# Altium schematic sheet FMC.SchDoc(FMC)
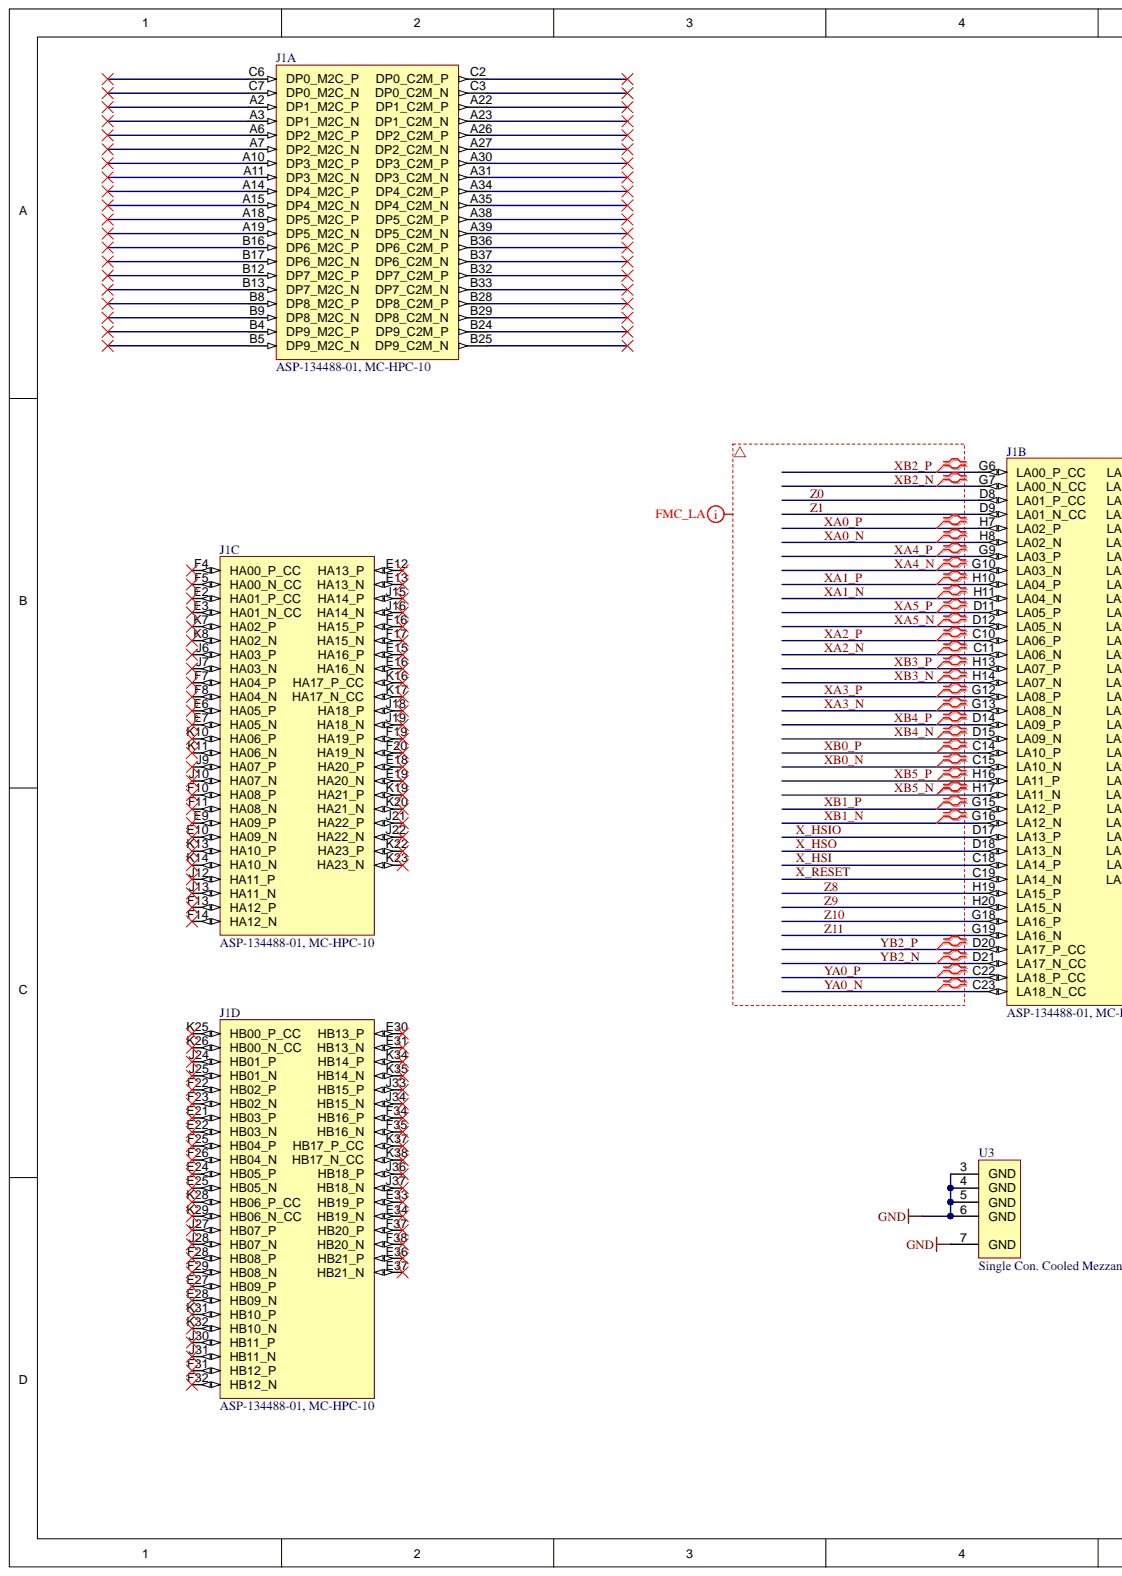
[diagram p0: FMC.SchDoc, left]
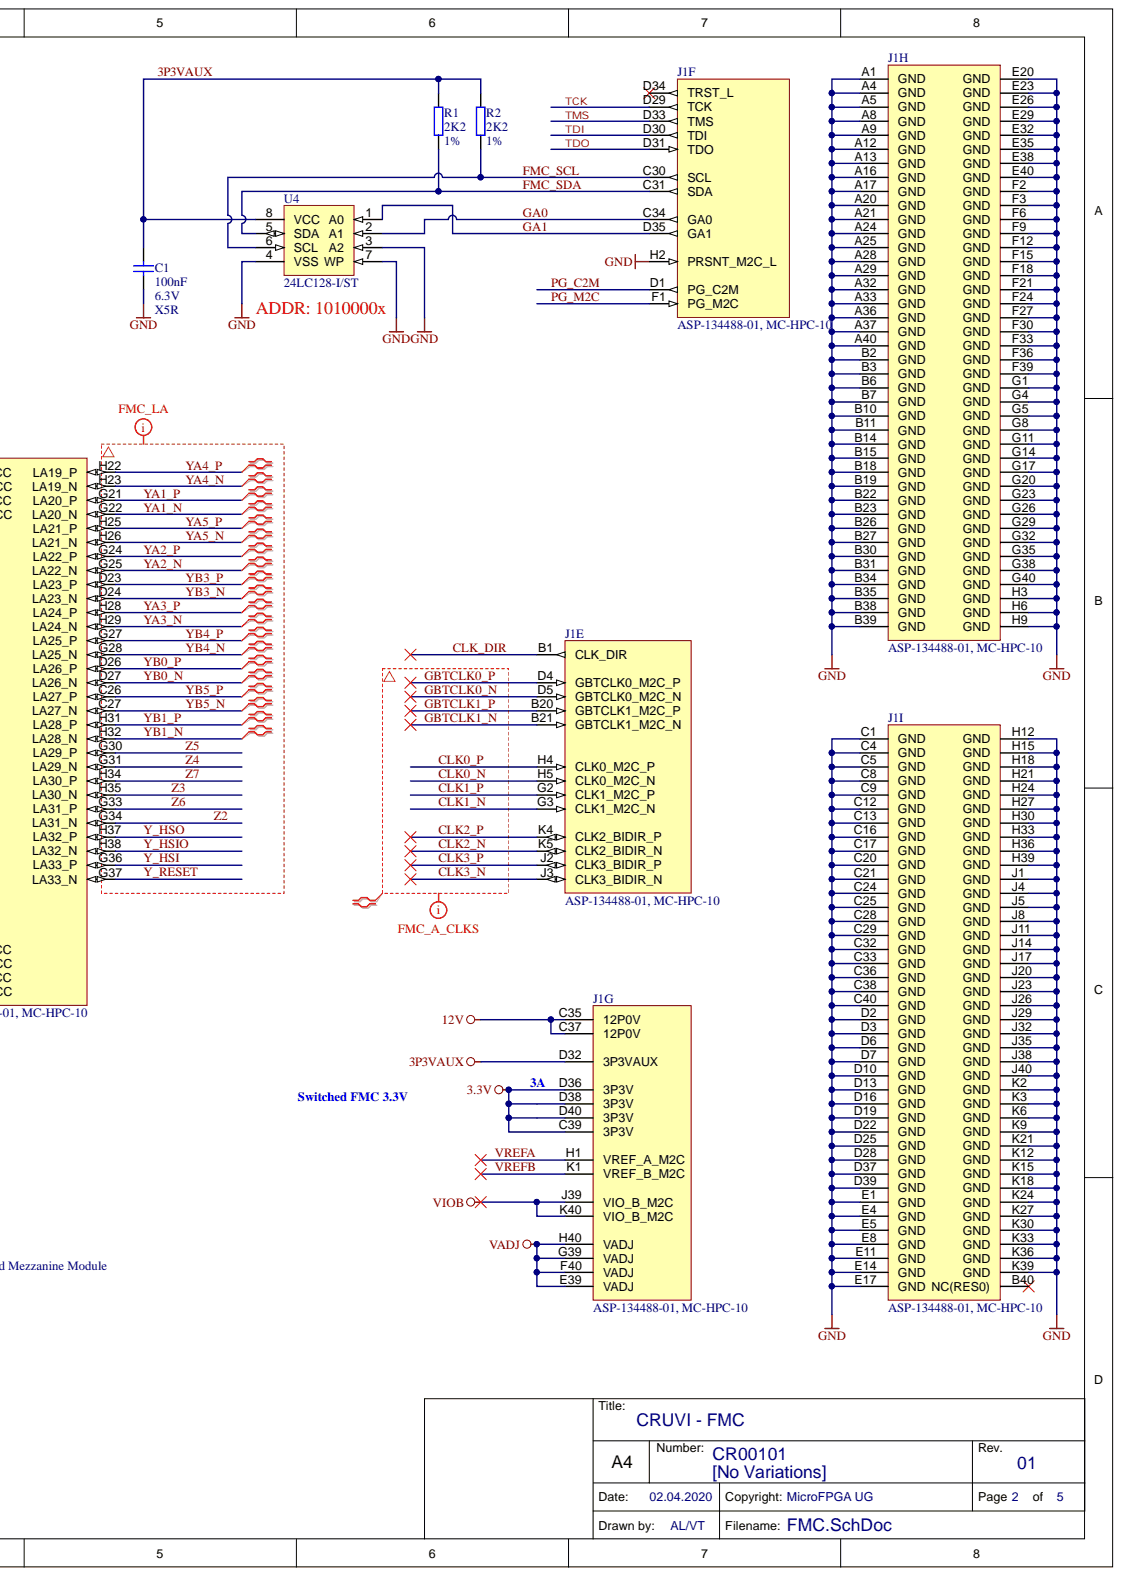
[diagram p1: FMC.SchDoc, right]

## Parts
C1  100nF  Technology: X5R  Voltage: 6.3V  [CAPC0603X33N]
J1  ASP-134488-01, MC-HPC-10  [ASP-134488-01]  (parts A,B,C,D,E,F,G,H,I)
R1  2K2  Tolerance: 1%  [RESC1005X40N]
R2  2K2  Tolerance: 1%  [RESC1005X40N]
U3  Single Con. Cooled Mezzanine Module  Case: Single  [FMC-MM-Single]
U4  24LC128-I/ST  [SOP65P640X120-8N-MC]

## Nets
3.3V: J1.C39(3P3V) J1.D36(3P3V) J1.D38(3P3V) J1.D40(3P3V)
12V: J1.C35(12P0V) J1.C37(12P0V)
GND: C1.1 J1.A1(GND) J1.A4(GND) J1.A5(GND) J1.A8(GND) J1.A9(GND) J1.A12(GND) J1.A13(GND) J1.A16(GND) J1.A17(GND) J1.A20(GND) J1.A21(GND) J1.A24(GND) J1.A25(GND) J1.A28(GND) J1.A29(GND) J1.A32(GND) J1.A33(GND) J1.A36(GND) J1.A37(GND) J1.A40(GND) J1.B2(GND) J1.B3(GND) J1.B6(GND) J1.B7(GND) J1.B10(GND) J1.B11(GND) J1.B14(GND) J1.B15(GND) J1.B18(GND) J1.B19(GND) J1.B22(GND) J1.B23(GND) J1.B26(GND) J1.B27(GND) J1.B30(GND) J1.B31(GND) J1.B34(GND) J1.B35(GND) J1.B38(GND) J1.B39(GND) J1.C1(GND) J1.C4(GND) J1.C5(GND) J1.C8(GND) J1.C9(GND) J1.C12(GND) J1.C13(GND) J1.C16(GND) J1.C17(GND) J1.C20(GND) J1.C21(GND) J1.C24(GND) J1.C25(GND) J1.C28(GND) J1.C29(GND) J1.C32(GND) J1.C33(GND) J1.C36(GND) J1.C38(GND) J1.C40(GND) J1.D2(GND) J1.D3(GND) J1.D6(GND) J1.D7(GND) J1.D10(GND) J1.D13(GND) J1.D16(GND) J1.D19(GND) J1.D22(GND) J1.D25(GND) J1.D28(GND) J1.D37(GND) J1.D39(GND) J1.E1(GND) J1.E4(GND) J1.E5(GND) J1.E8(GND) J1.E11(GND) J1.E14(GND) J1.E17(GND) J1.E20(GND) J1.E23(GND) J1.E26(GND) J1.E29(GND) J1.E32(GND) J1.E35(GND) J1.E38(GND) J1.E40(GND) J1.F2(GND) J1.F3(GND) J1.F6(GND) J1.F9(GND) J1.F12(GND) J1.F15(GND) J1.F18(GND) J1.F21(GND) J1.F24(GND) J1.F27(GND) J1.F30(GND) J1.F33(GND) J1.F36(GND) J1.F39(GND) J1.G1(GND) J1.G4(GND) J1.G5(GND) J1.G8(GND) J1.G11(GND) J1.G14(GND) J1.G17(GND) J1.G20(GND) J1.G23(GND) J1.G26(GND) J1.G29(GND) J1.G32(GND) J1.G35(GND) J1.G38(GND) J1.G40(GND) J1.H2(PRSNT_M2C_L) J1.H3(GND) J1.H6(GND) J1.H9(GND) J1.H12(GND) J1.H15(GND) J1.H18(GND) J1.H21(GND) J1.H24(GND) J1.H27(GND) J1.H30(GND) J1.H33(GND) J1.H36(GND) J1.H39(GND) J1.J1(GND) J1.J4(GND) J1.J5(GND) J1.J8(GND) J1.J11(GND) J1.J14(GND) J1.J17(GND) J1.J20(GND) J1.J23(GND) J1.J26(GND) J1.J29(GND) J1.J32(GND) J1.J35(GND) J1.J38(GND) J1.J40(GND) J1.K2(GND) J1.K3(GND) J1.K6(GND) J1.K9(GND) J1.K12(GND) J1.K15(GND) J1.K18(GND) J1.K21(GND) J1.K24(GND) J1.K27(GND) J1.K30(GND) J1.K33(GND) J1.K36(GND) J1.K39(GND) U3.3(GND) U3.4(GND) U3.5(GND) U3.6(GND) U3.7(GND) U4.3(A2) U4.4(VSS) U4.7(WP)
VADJ: J1.E39(VADJ) J1.F40(VADJ) J1.G39(VADJ) J1.H40(VADJ)
VIOB: J1.J39(VIO_B_M2C) J1.K40(VIO_B_M2C)
3P3VAUX: C1.2 J1.D32(3P3VAUX) R1.1 R2.1 U4.8(VCC)
CLK0_N: J1.H5(CLK0_M2C_N)
CLK0_P: J1.H4(CLK0_M2C_P)
CLK1_N: J1.G3(CLK1_M2C_N)
CLK1_P: J1.G2(CLK1_M2C_P)
CLK2_N: J1.K5(CLK2_BIDIR_N)
CLK2_P: J1.K4(CLK2_BIDIR_P)
CLK3_N: J1.J3(CLK3_BIDIR_N)
CLK3_P: J1.J2(CLK3_BIDIR_P)
CLK_DIR: J1.B1(CLK_DIR)
FMC_SCL: J1.C30(SCL) R2.2 U4.6(SCL)
FMC_SDA: J1.C31(SDA) R1.2 U4.5(SDA)
GA0: J1.C34(GA0) U4.2(A1)
GA1: J1.D35(GA1) U4.1(A0)
GBTCLK0_N: J1.D5(GBTCLK0_M2C_N)
GBTCLK0_P: J1.D4(GBTCLK0_M2C_P)
GBTCLK1_N: J1.B21(GBTCLK1_M2C_N)
GBTCLK1_P: J1.B20(GBTCLK1_M2C_P)
PG_C2M: J1.D1(PG_C2M)
PG_M2C: J1.F1(PG_M2C)
TCK: J1.D29(TCK)
TDI: J1.D30(TDI)
TDO: J1.D31(TDO)
TMS: J1.D33(TMS)
VREFA: J1.H1(VREF_A_M2C)
VREFB: J1.K1(VREF_B_M2C)
X_HSI: J1.C18(LA14_P)
X_HSIO: J1.D17(LA13_P)
X_HSO: J1.D18(LA13_N)
X_RESET: J1.C19(LA14_N)
XA0_N: J1.H8(LA02_N)
XA0_P: J1.H7(LA02_P)
XA1_N: J1.H11(LA04_N)
XA1_P: J1.H10(LA04_P)
XA2_N: J1.C11(LA06_N)
XA2_P: J1.C10(LA06_P)
XA3_N: J1.G13(LA08_N)
XA3_P: J1.G12(LA08_P)
XA4_N: J1.G10(LA03_N)
XA4_P: J1.G9(LA03_P)
XA5_N: J1.D12(LA05_N)
XA5_P: J1.D11(LA05_P)
XB0_N: J1.C15(LA10_N)
XB0_P: J1.C14(LA10_P)
XB1_N: J1.G16(LA12_N)
XB1_P: J1.G15(LA12_P)
XB2_N: J1.G7(LA00_N_CC)
XB2_P: J1.G6(LA00_P_CC)
XB3_N: J1.H14(LA07_N)
XB3_P: J1.H13(LA07_P)
XB4_N: J1.D15(LA09_N)
XB4_P: J1.D14(LA09_P)
XB5_N: J1.H17(LA11_N)
XB5_P: J1.H16(LA11_P)
Y_HSI: J1.G36(LA33_P)
Y_HSIO: J1.H38(LA32_N)
Y_HSO: J1.H37(LA32_P)
Y_RESET: J1.G37(LA33_N)
YA0_N: J1.C23(LA18_N_CC)
YA0_P: J1.C22(LA18_P_CC)
YA1_N: J1.G22(LA20_N)
YA1_P: J1.G21(LA20_P)
YA2_N: J1.G25(LA22_N)
YA2_P: J1.G24(LA22_P)
YA3_N: J1.H29(LA24_N)
YA3_P: J1.H28(LA24_P)
YA4_N: J1.H23(LA19_N)
YA4_P: J1.H22(LA19_P)
YA5_N: J1.H26(LA21_N)
YA5_P: J1.H25(LA21_P)
YB0_N: J1.D27(LA26_N)
YB0_P: J1.D26(LA26_P)
YB1_N: J1.H32(LA28_N)
YB1_P: J1.H31(LA28_P)
YB2_N: J1.D21(LA17_N_CC)
YB2_P: J1.D20(LA17_P_CC)
YB3_N: J1.D24(LA23_N)
YB3_P: J1.D23(LA23_P)
YB4_N: J1.G28(LA25_N)
YB4_P: J1.G27(LA25_P)
YB5_N: J1.C27(LA27_N)
YB5_P: J1.C26(LA27_P)
Z0: J1.D8(LA01_P_CC)
Z1: J1.D9(LA01_N_CC)
Z2: J1.G34(LA31_N)
Z3: J1.H35(LA30_N)
Z4: J1.G31(LA29_N)
Z5: J1.G30(LA29_P)
Z6: J1.G33(LA31_P)
Z7: J1.H34(LA30_P)
Z8: J1.H19(LA15_P)
Z9: J1.H20(LA15_N)
Z10: J1.G18(LA16_P)
Z11: J1.G19(LA16_N)
N00125:
N00126:
N00127:
N00128:

Not connected: J1 A2(DP1_M2C_P) A3(DP1_M2C_N) A6(DP2_M2C_P) A7(DP2_M2C_N) A10(DP3_M2C_P) A11(DP3_M2C_N) A14(DP4_M2C_P) A15(DP4_M2C_N) A18(DP5_M2C_P) A19(DP5_M2C_N) A22(DP1_C2M_P) A23(DP1_C2M_N) A26(DP2_C2M_P) A27(DP2_C2M_N) A30(DP3_C2M_P) A31(DP3_C2M_N) A34(DP4_C2M_P) A35(DP4_C2M_N) A38(DP5_C2M_P) A39(DP5_C2M_N) B4(DP9_M2C_P) B5(DP9_M2C_N) B8(DP8_M2C_P) B9(DP8_M2C_N) B12(DP7_M2C_P) B13(DP7_M2C_N) B16(DP6_M2C_P) B17(DP6_M2C_N) B24(DP9_C2M_P) B25(DP9_C2M_N) B28(DP8_C2M_P) B29(DP8_C2M_N) B32(DP7_C2M_P) B33(DP7_C2M_N) B36(DP6_C2M_P) B37(DP6_C2M_N) B40(NC(RES0)) C2(DP0_C2M_P) C3(DP0_C2M_N) C6(DP0_M2C_P) C7(DP0_M2C_N) D34(TRST_L) E2(HA01_P_CC) E3(HA01_N_CC) E6(HA05_P) E7(HA05_N) E9(HA09_P) E10(HA09_N) E12(HA13_P) E13(HA13_N) E15(HA16_P) E16(HA16_N) E18(HA20_P) E19(HA20_N) E21(HB03_P) E22(HB03_N) E24(HB05_P) E25(HB05_N) E27(HB09_P) E28(HB09_N) E30(HB13_P) E31(HB13_N) E33(HB19_P) E34(HB19_N) E36(HB21_P) E37(HB21_N) F4(HA00_P_CC) F5(HA00_N_CC) F7(HA04_P) F8(HA04_N) F10(HA08_P) F11(HA08_N) F13(HA12_P) F14(HA12_N) F16(HA15_P) F17(HA15_N) F19(HA19_P) F20(HA19_N) F22(HB02_P) F23(HB02_N) F25(HB04_P) F26(HB04_N) F28(HB08_P) F29(HB08_N) F31(HB12_P) F32(HB12_N) F34(HB16_P) F35(HB16_N) F37(HB20_P) F38(HB20_N) J6(HA03_P) J7(HA03_N) J9(HA07_P) J10(HA07_N) J12(HA11_P) J13(HA11_N) J15(HA14_P) J16(HA14_N) J18(HA18_P) J19(HA18_N) J21(HA22_P) J22(HA22_N) J24(HB01_P) J25(HB01_N) J27(HB07_P) J28(HB07_N) J30(HB11_P) J31(HB11_N) J33(HB15_P) J34(HB15_N) J36(HB18_P) J37(HB18_N) K7(HA02_P) K8(HA02_N) K10(HA06_P) K11(HA06_N) K13(HA10_P) K14(HA10_N) K16(HA17_P_CC) K17(HA17_N_CC) K19(HA21_P) K20(HA21_N) K22(HA23_P) K23(HA23_N) K25(HB00_P_CC) K26(HB00_N_CC) K28(HB06_P_CC) K29(HB06_N_CC) K31(HB10_P) K32(HB10_N) K34(HB14_P) K35(HB14_N) K37(HB17_P_CC) K38(HB17_N_CC)

## Library data of the parts
C1 = 24915: KON 100nF 6.3V X5R SMD 10%; Database Table Name: C_0603_0201; Mixed: SMT; Preferred: Yes; Value: 100n; AN: 24915; Case: 0603 (0201); Component Kind: Standard; Library Name: TE.DbLib; Tolerance: 10%
J1 = 24998: ASP-134488-01  male, MC-HPC-10; Database Table Name: CON_B2B; Mixed: SMT; Trenz-Stock: Yes; AN: 24998; Component Kind: Standard; H-ART: ASP-134488-01; Library Name: TE.DbLib; HelpURL: B:\AD\adlib\datasheet\ASP-134488-01
R1 R2 = 22748: WID SMD 2K2 1% 0,063W; Database Table Name: R_1005_0402; Mixed: SMT; Preferred: Yes; Value: 2.2K; AN: 22748; Case: 1005 (0402); Component Kind: Standard; Library Name: TE.DbLib; Technology: TK100
U3 = 23811: FMC-MM-Single Conduction Cooled PCB Module Snap; Mixed: THT; AN: 23811; Component Kind: Standard; Database Table Name: PCB; Library Name: TE.DbLib; HelpURL: B:\AD\adlib\datasheet\FMC Mezzanine Module
U4 = 22933: Database Table Name: EEPROM; Mixed: SMT; Preferred: Yes; AN: 22933; Case: TSSOP8; Component Kind: Standard; Description: 24LC128-I/ST; H-ART: 24LC128-I/ST; Library Name: TE.DbLib; Trenz-Stock: Yes; HelpURL: B:\AD\adlib\datasheet\24LC128
